# Altium schematic sheet Power supply.SchDoc
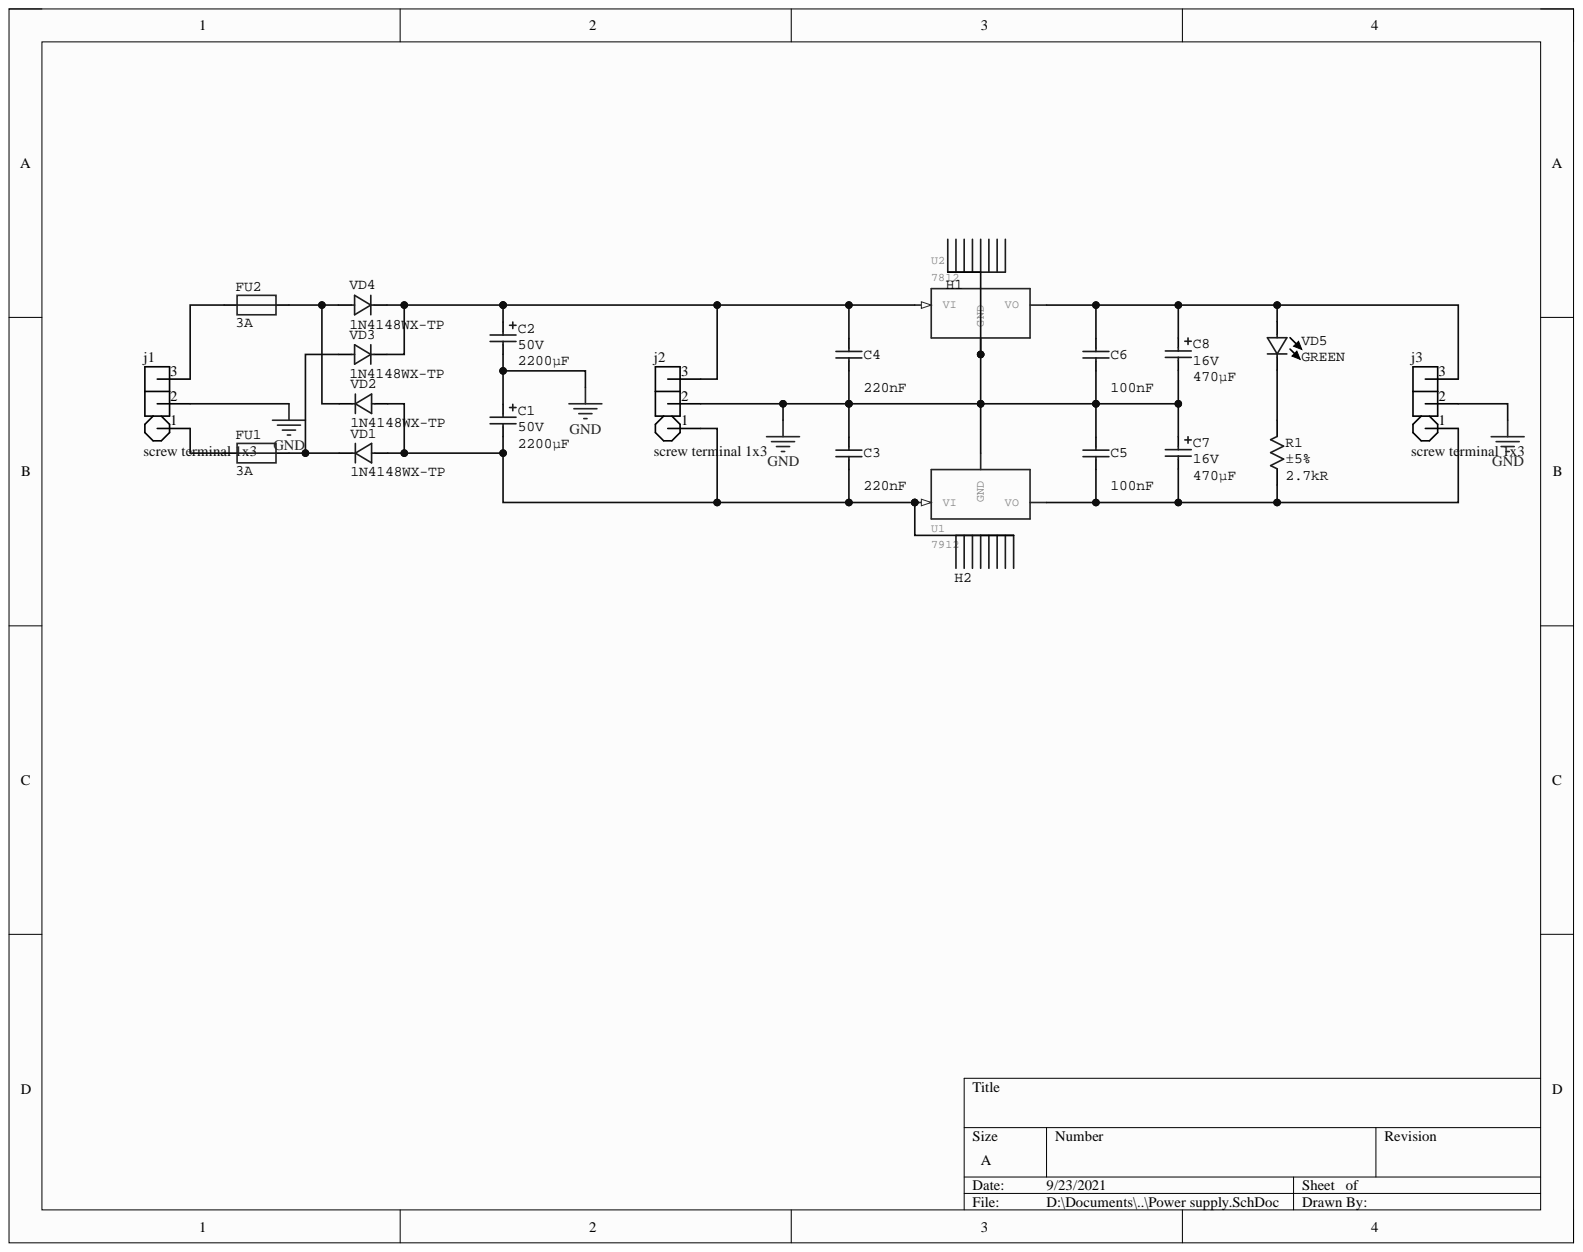
[diagram p0: Power supply.SchDoc]

## Parts
C1  value 2200μF  Voltage: 50V  [CAP Electrolytic TH D16C7.5H31.5]  (hidden comment: Aluminium capacitor, 2200μF, 50V, ±20%, 54mOhm, 14x10x5 mm, -55...+105°C)
C2  value 2200μF  Voltage: 50V  [CAP Electrolytic TH D16C7.5H31.5]  (hidden comment: Aluminium capacitor, 2200μF, 50V, ±20%, 54mOhm, 14x10x5 mm, -55...+105°C)
C3  value 220nF  [CAP Ceramic TH AY2102M29Y5US63L7]  (hidden comment: Film capacitor, 220nF, 450VDC, ±10%, 10.9mOhm, 31.5x15 mm, -40...+105°C)
C4  value 220nF  [CAP Ceramic TH AY2102M29Y5US63L7]  (hidden comment: Film capacitor, 220nF, 450VDC, ±10%, 10.9mOhm, 31.5x15 mm, -40...+105°C)
C5  value 100nF  [CAP Ceramic TH AY2102M29Y5US63L7]  (hidden comment: Film capacitor, 100nF, 450VDC, ±10%, 10.9mOhm, 31.5x15 mm, -40...+105°C)
C6  value 100nF  [CAP Ceramic TH AY2102M29Y5US63L7]  (hidden comment: Film capacitor, 100nF, 450VDC, ±10%, 10.9mOhm, 31.5x15 mm, -40...+105°C)
C7  value 470μF  Voltage: 16V  [CAP Electrolytic TH D10C5H12.5]  (hidden comment: Aluminium capacitor, 470μF, 16V, ±20%, 54mOhm, 14x10x5 mm, -55...+105°C)
C8  value 470μF  Voltage: 16V  [CAP Electrolytic TH D10C5H12.5]  (hidden comment: Aluminium capacitor, 470μF, 16V, ±20%, 54mOhm, 14x10x5 mm, -55...+105°C)
FU1  MaxCurrent: 3A  [FUSE TH BLX-A]  (hidden comment: Electromechanics relay, 250VAC, 3A, 5x20mm,)
FU2  MaxCurrent: 3A  [FUSE TH BLX-A]  (hidden comment: Electromechanics relay, 250VAC, 3A, 5x20mm,)
H1  Heatsink 1 pad transistor TO220  [Heatsink 1 pad SK95-2M3]  (value not drawn)
H2  Heatsink 1 pad transistor TO220  [Heatsink 1 pad SK95-2M3]  (value not drawn)
j1  screw terminal 1x3  [screw terminal 1x3]
j2  screw terminal 1x3  [screw terminal 1x3]
j3  screw terminal 1x3  [screw terminal 1x3]
R1  value 2.7kR  Tolerance: ±5%  [RES TH 0.25W H 0207$10]  (hidden comment: Resistor film, 2.7kR, ±5%, 0201, 0.25W, -55...+125°C)
U1  7912  [Linear reagulator TH TO220V]
U2  7812  [Linear reagulator TH TO220V]
VD1  PartNumber: 1N4148WX-TP  [Diode TH DO-41 (h) (1n4007)]  (hidden comment: Single diode, 75V, 150mA, 1V, 4ns, SOD-323, -55...+150°C)
VD2  PartNumber: 1N4148WX-TP  [Diode TH DO-41 (h) (1n4007)]  (hidden comment: Single diode, 75V, 150mA, 1V, 4ns, SOD-323, -55...+150°C)
VD3  PartNumber: 1N4148WX-TP  [Diode TH DO-41 (h) (1n4007)]  (hidden comment: Single diode, 75V, 150mA, 1V, 4ns, SOD-323, -55...+150°C)
VD4  PartNumber: 1N4148WX-TP  [Diode TH DO-41 (h) (1n4007)]  (hidden comment: Single diode, 75V, 150mA, 1V, 4ns, SOD-323, -55...+150°C)
VD5  Color: GREEN  [LED TH 5MM]  (hidden comment: LED, Green, *, *, *, -55...+150°C)

## Nets
GND: C1.1 C2.2 C3.1 C4.2 C5.1 C6.2 C7.1 C8.2 H1.1 j1.2 j2.2 j3.2 U1.1 U2.2
NetC1_2: C1.2 C3.2 H2.1 j2.1 U1.2 VD1.1 VD2.1
NetC2_1: C2.1 C4.1 j2.3 U2.1 VD3.2 VD4.2
NetC5_2: C5.2 C7.2 j3.1 R1.1 U1.3
NetC6_1: C6.1 C8.1 j3.3 U2.3 VD5.1
NetFU1_1: FU1.1 j1.1
NetFU1_2: FU1.2 VD1.2 VD3.1
NetFU2_1: FU2.1 j1.3
NetFU2_2: FU2.2 VD2.2 VD4.1
NetR1_2: R1.2 VD5.2

## Library data of the parts
C1 C2 C7 C8 = Capacitor Aluminium: Manufacturer: Wurth; PartNumber: 860080475013; Component Kind: Standard; HelpURL: https://www.digikey.com/product-detail/en/wurth-electronics-inc/860080475013/732-9029-1-ND/5728972; Impedance: 54mOhm; Library Name: Passive.IntLib; Package: 14x10x5 mm; Temperature: -55...+105°C; Tolerance: ±20%; TypeCapacitor: Aluminium capacitor
  C1 C2: ValueManufacturerPartNumber: Aluminium capacitor, 2200μF, 50V, ±20%, 54mOhm, 14x10x5 mm, -55...+105°C
  C7 C8: ValueManufacturerPartNumber: Aluminium capacitor, 470μF, 16V, ±20%, 54mOhm, 14x10x5 mm, -55...+105°C
C3-C6 = Capacitor Film: Manufacturer: Epcos; PartNumber: B32774D4106K000; Component Kind: Standard; HelpURL: https://www.digikey.com/products/en/capacitors/film-capacitors/62?k=&pkeyword=&sv=0&pv2097=1958&sf=0&FV=fffc01ef%2C1f140000%2Cffe0003e%2Cffec6366&quantity=1&ColumnSort=1000011&page=1&stock=1&pageSize=25; Impedance: 10.9mOhm; Library Name: Passive.IntLib; Package: 31.5x15 mm; Temperature: -40...+105°C; Tolerance: ±10%; TypeCapacitor: Film capacitor; Voltage: 450VDC
  C3 C4: ValueManufacturerPartNumber: Film capacitor, 220nF, 450VDC, ±10%, 10.9mOhm, 31.5x15 mm, -40...+105°C
  C5 C6: ValueManufacturerPartNumber: Film capacitor, 100nF, 450VDC, ±10%, 10.9mOhm, 31.5x15 mm, -40...+105°C
FU1 FU2 = Fuse: Manufacturer: Littelfuse; PartNumber: 01110501Z; Component Kind: Standard; HelpURL: https://www.digikey.com/products/en?keywords=01110501Z; Library Name: Fuse.IntLib; Package: 5x20mm; TypeFuse: Electromechanics relay; ValueManufacturerPartNumber: Electromechanics relay, 250VAC, 3A, 5x20mm,; VoltageMax: 250VAC
j1-j3 = screw terminal 1x3: PCB Terminal Block 3 Poles, 5 mm Pitch, 691101710003, Würth Elektronik; Manufacturer: Wurth Electronics; Current: 16A; Number of Contacts: 3; Number of Levels: 1; Pitch: 0.197inch; Plating: Steel; Stripping Length: -7mm; Voltage: 250V; Wire Gauge (Max): 26AWG; Wire Gauge (Min): 14AWG; Category: Terminal Blocks; Color: Blue; Component Kind: Standard; ComponentLink1Description: https://datasheet.ciiva.com/824/6911017100xx-824072.pdf?src-supplier=Digi-Key; ComponentLink1URL: https://datasheet.ciiva.com/824/6911017100xx-824072.pdf?src-supplier=Digi-Key; Contact Material: Brass; Contact Plating: Tin; Current Rating: 16A; Height: 16.4mm; Housing Material: PA66; Length: 9mm; Library Name: connectors.IntLib; Manufacturer Part Number: 691101710003; Max Operating Temperature: 105°C; Min Operating Temperature: -40°C; Mount: Through Hole; Number of Positions Per Level: 3; Package Quantity: 400; Packaging: Bulk; Pricing 1: 1=0.96000, 10=0.86200, 50=0.74500, 100=0.69120 (USD); REACH SVHC: Unknown; RoHS: Yes; RoHS Compliant: Yes; Series: WR-TBL Series 101; Stock 1: 955; Supplier 1: Digi-Key; Supplier Part Number 1: 732-2027-ND; Termination: Screw; Voltage Rating: 250V; Width: 16.2mm; Wire/Cable Gauge: 26AWG
R1 = Resistor Fixed: Manufacturer: Yageo; PartNumber: RC0201JR-07100RL; Component Kind: Standard; HelpURL: https://www.digikey.com/product-detail/en/yageo/RC0201JR-07100RL/311-100NCT-ND/1949006; Library Name: Passive.IntLib; Package: 0201; Power: 0.25W; Temperature: -55...+125°C; TypeResistor: Resistor film; ValueManufacturerPartNumber: Resistor film, 2.7kR, ±5%, 0201, 0.25W, -55...+125°C
U1 = 79XX: Negative VOLTAGE REGULATOR; DeviceName: H; DeviceSetName: 79*; GateName_1: A1; POPULARITY: 0; Component Kind: Standard; Library Name: Linear regulator.IntLib; LibraryName: Linear regulator; Package: TO39; SymbolName_1: 79XX
U2 = 78XX: Positive VOLTAGE REGULATOR; DeviceName: DT; DeviceSetName: 78*; GateName_1: A1; POPULARITY: 0; Component Kind: Standard; Library Name: Linear regulator.IntLib; LibraryName: Linear regulator; Package: TO252; SymbolName_1: 78XX
VD1-VD4 = Diode single: Manufacturer: Micro Commercial; Component Kind: Standard; Current: 150mA; HelpURL: https://www.digikey.com/product-detail/en/micro-commercial-co/1N4148WX-TP/1N4148WXTPMSCT-ND/717312; Library Name: diode.IntLib; Package: SOD-323; RecoveryTime: 4ns; Temperature: -55...+150°C; TypeDiode: Single diode; ValueManufacturerPartNumber: Single diode, 75V, 150mA, 1V, 4ns, SOD-323, -55...+150°C; Voltage: 75V; VoltageDrop: 1V
VD5 = LED single: ColorParameter: Green; Component Kind: Standard; Library Name: diode.IntLib; Temperature: -55...+150°C; TypeDiode: LED; ValueManufacturerPartNumber: LED, Green, *, *, *, -55...+150°C
H1 H2 = Heatsink 1 pad transistor TO220: Component Kind: Standard; Library Name: Heatsinks.IntLib
